# Altium schematic sheet RJ45.SchDoc(RJ45)
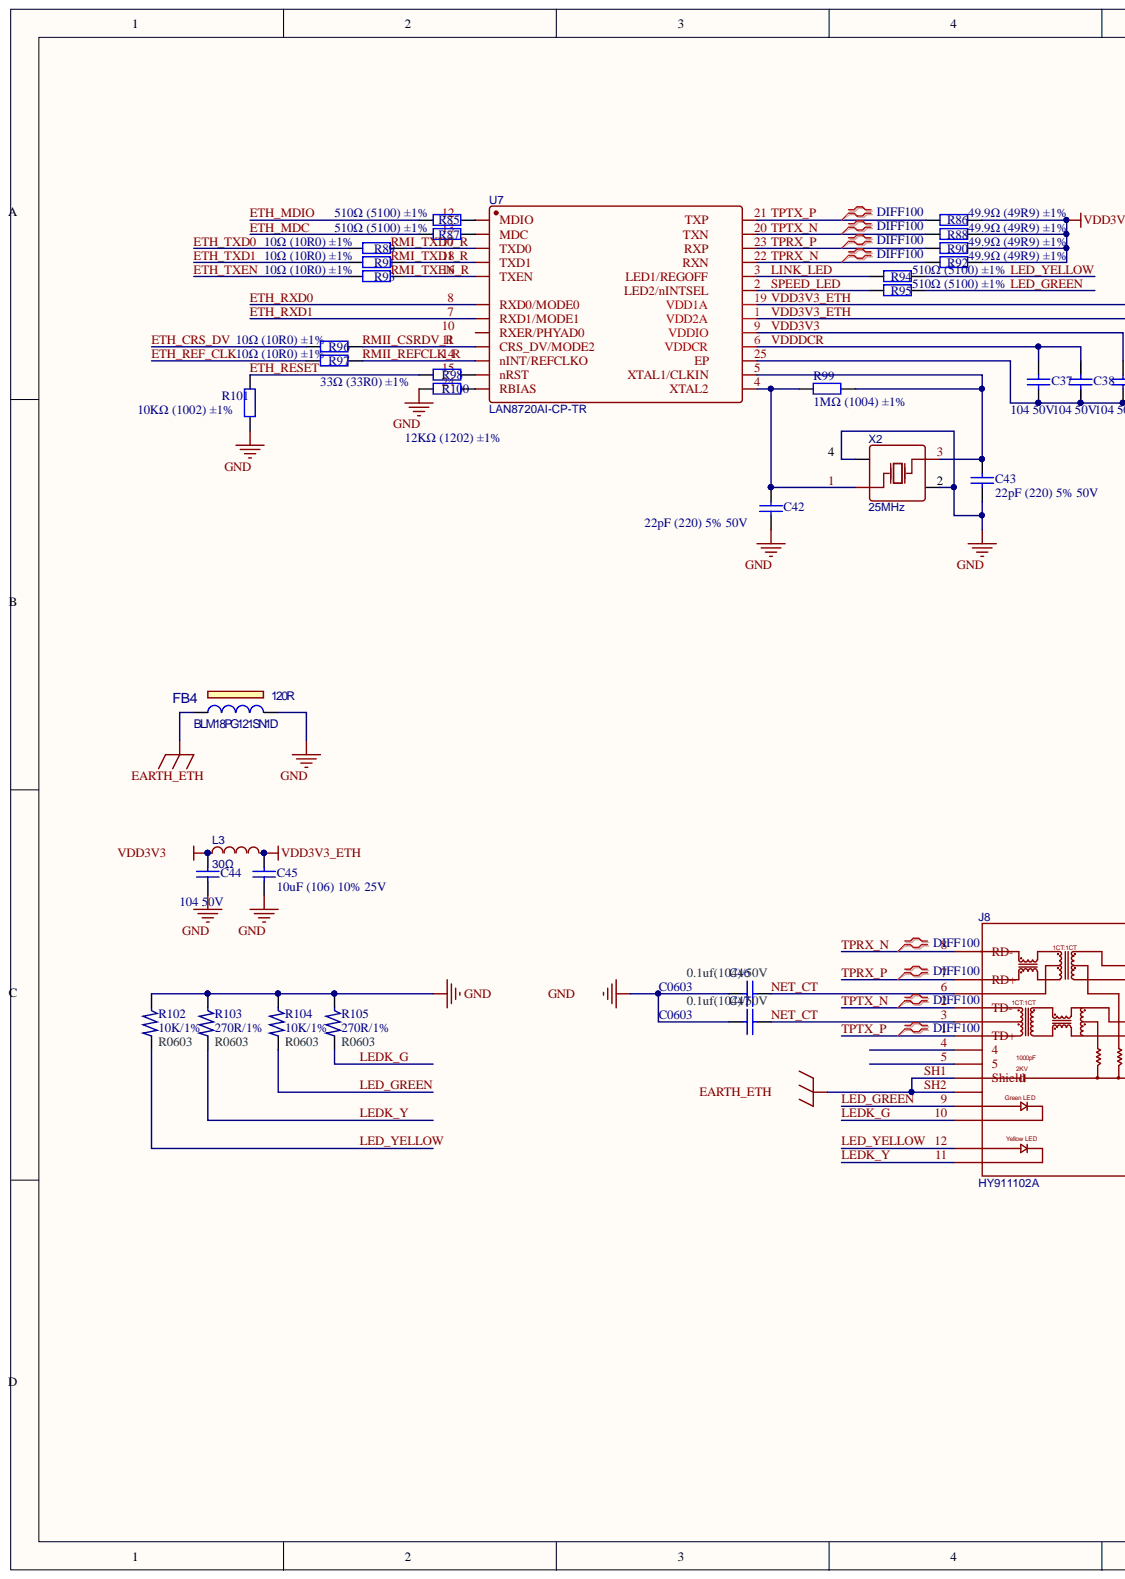
[diagram p0: RJ45.SchDoc, left]
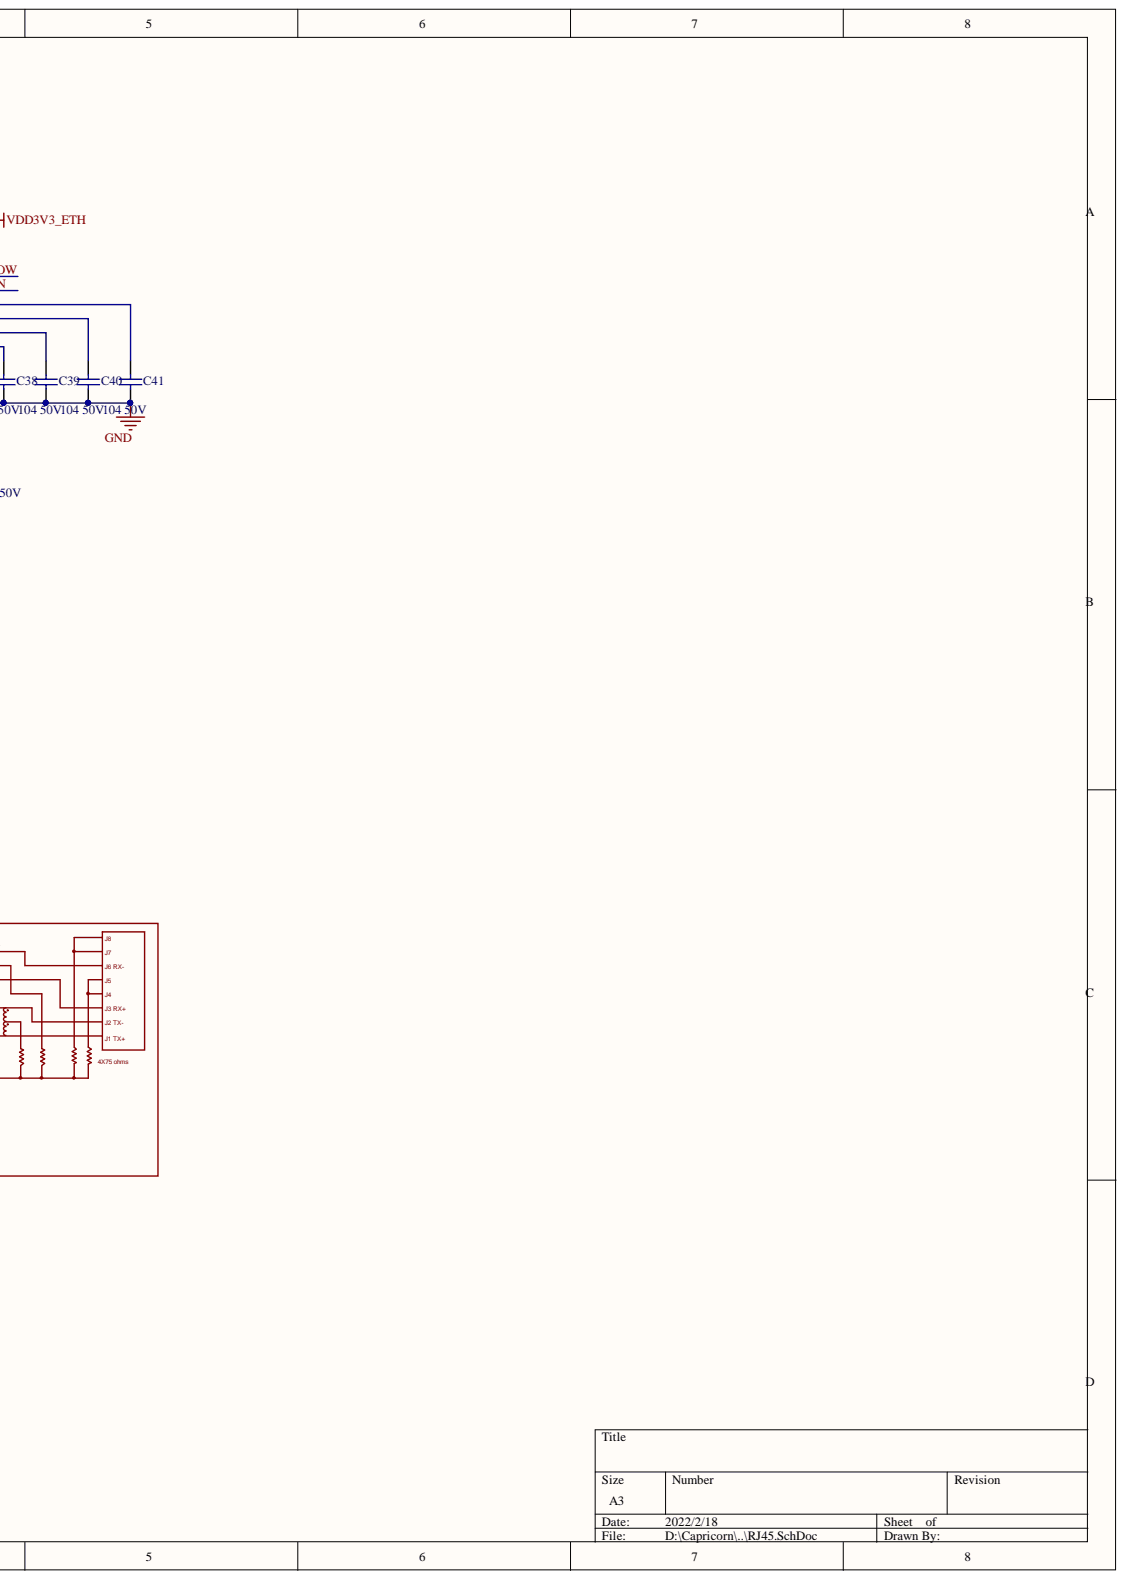
[diagram p1: RJ45.SchDoc, right]

## Parts
C37  104 50V  [0603_C]
C38  104 50V  [0603_C]
C39  104 50V  [0603_C]
C40  104 50V  [0603_C]
C41  104 50V  [0603_C]
C42  22pF (220) 5% 50V  [0603_C]
C43  22pF (220) 5% 50V  [0603_C]
C44  104 50V  [0603_C]
C45  10uF (106) 10% 25V  [0805_C]
C46  0.1uf(104)/50V  value C0603  [0603_C]
C47  0.1uf(104)/50V  value C0603  [0603_C]
FB4  value 120R  Manufacturer Part Number 1: BLM18PG121SN1D  [0603_L]  (hidden comment: Ferrite Bead)
J8  HY911102A  [RJ45-TH_HR911105A]
L3  30Ω  [0603_L]
R85  510Ω (5100) ±1%  [0603_R]
R86  49.9Ω (49R9) ±1%  [0603_R]
R87  510Ω (5100) ±1%  [0603_R]
R88  49.9Ω (49R9) ±1%  [0603_R]
R89  10Ω (10R0) ±1%  [0603_R]
R90  49.9Ω (49R9) ±1%  [0603_R]
R91  10Ω (10R0) ±1%  [0603_R]
R92  49.9Ω (49R9) ±1%  [0603_R]
R93  10Ω (10R0) ±1%  [0603_R]
R94  510Ω (5100) ±1%  [0603_R]
R95  510Ω (5100) ±1%  [0603_R]
R96  10Ω (10R0) ±1%  [0603_R]
R97  10Ω (10R0) ±1%  [0603_R]
R98  33Ω (33R0) ±1%  [0603_R]
R99  1MΩ (1004) ±1%  [0603_R]
R100  12KΩ (1202) ±1%  [0603_R]
R101  10KΩ (1002) ±1%  [0603_R]
R102  10K/1%  [R0603]
R103  270R/1%  [R0603]
R104  10K/1%  [R0603]
R105  270R/1%  [R0603]
U7  LAN8720AI-CP-TR  [QFN-24_4x4x05P]
X2  25MHz  [OSC-SMD_4P-L3.2-W2.5-BL]

## Nets
EARTH_ETH: FB4.1 J8.SH1(Shield) J8.SH2
GND: C37.1 C38.1 C39.1 C40.1 C41.1 C42.1 C43.1 C44.1 C45.1 C46.2 C47.2 FB4.2 R100.1 R101.1 R102.2 R103.2 R104.2 R105.2 U7.25 X2.2 X2.4
ETH_CRS_DV: R96.1
ETH_MDC: R87.1
ETH_MDIO: R85.1
ETH_REF_CLK: R97.1
ETH_RESET: R98.1 R101.2
ETH_RXD0: U7.8(RXD0/MODE0)
ETH_RXD1: U7.7(RXD1/MODE1)
ETH_TXD0: R89.1
ETH_TXD1: R91.1
ETH_TXEN: R93.1
LED_GREEN: J8.9 R95.2 R104.1
LED_YELLOW: J8.12 R94.2 R102.1
LEDK_G: J8.10 R105.1
LEDK_Y: J8.11 R103.1
LINK_LED: R94.1 U7.3
NET_CT: C46.1 C47.1 J8.3 J8.6
RMII_CSRDV_R: R96.2 U7.11(CRS_DV/MODE2)
RMII_REFCLK_R: R97.2 U7.14(nINT/REFCLKO)
SPEED_LED: R95.1 U7.2
TPRX_N: J8.8(RD-) R92.1 U7.22
TPRX_P: J8.7(RD+) R90.1 U7.23
TPTX_N: J8.2(TD-) R88.1 U7.20
TPTX_P: J8.1(TD+) R86.1 U7.21
VDD3V3: C39.2 C44.2 L3.1 U7.9
VDD3V3_ETH: C40.2 C41.2 C45.2 L3.2 R86.2 R88.2 R90.2 R92.2 U7.1 U7.19
VDDDCR: C37.2 C38.2 U7.6
NetC42_2: C42.2 R99.1 U7.4 X2.1
NetC43_2: C43.2 R99.2 U7.5 X2.3
NetR85_2: R85.2 U7.12(MDIO)
NetR87_2: R87.2 U7.13(MDC)
NetR89_2: R89.2 U7.17(TXD0)
NetR91_2: R91.2 U7.18(TXD1)
NetR93_2: R93.2 U7.16(TXEN)
NetR98_2: R98.2 U7.15(nRST)
NetR100_2: R100.2 U7.24(RBIAS)
RMI_TXD0_R:
RMI_TXD1_R:
RMI_TXEN_R:

Not connected: J8 4 5; U7 10(RXER/PHYAD0)

## Library data of the parts
C37-C41 C44 = 0603 100nF (104) 10% 50V: 贴片电容; Component Kind: Standard; ComponentLink1Description: 供应商链接; ComponentLink1URL: http://www.szlcsc.com/product/details_15331.html; Library Name: Miscellaneous Devices LC.IntLib; Package: 0603; Supplier: LC; SuppliersPartNumber: C14663
C42 C43 = 0603 22pF (220) 5% 50V: 贴片电容; Component Kind: Standard; ComponentLink1Description: 供应商链接; ComponentLink1URL: http://www.szlcsc.com/product/details_2005.html; Library Name: Miscellaneous Devices LC.IntLib; Package: 0603; Supplier: LC; SuppliersPartNumber: C1653
C45 = 0805 10uF (106) 10% 25V: 贴片电容; Component Kind: Standard; ComponentLink1Description: 供应商链接; ComponentLink1URL: http://www.szlcsc.com/product/details_16532.html; Library Name: Miscellaneous Devices LC.IntLib; Package: 0805; Supplier: LC; SuppliersPartNumber: C15850
C46 C47 = CAP: Capacitor; Component Kind: Standard
FB4 = IND1009: Manufacturer 1: Murata; Database Table Name: Inductor; DCR: 0.05R; Description_: FERRITE 120R@100MHz 2A SMD 0603; Dev Tools Status: Production; Owner: Petnarong Chaijaroong; Populated: Yes; Saturation Current: 12A; Category: Inductor; Component Kind: Standard; ComponentLink1Description: SVN Datasheet; ComponentLink1URL: https://chn-vm-apps.microchip.com/mchprepo/parts/Datasheets/L0110S0100BLM18P.pdf; ComponentLink2Description: Local Datasheet; ComponentLink3Description: Open Symbol; ComponentLink4Description: Open Footprint; ComponentLink5Description: Open Footprint 2; ComponentLink6Description: Open Footprint 3; ComponentLink7Description: Open Footprint 4; Library Name: MCHP-CDB.DbLib; MCHP-ID: IND1009; Operating Temperature: -55C to +125C; Package: 0603; Quantity Override: 1; Revision: 0; Status: MCL Design; Supplier 1: Digi-Key; Supplier Part Number 1: 490-1037-1-ND; Symbol: Ferrite Bead; Type: Ferrite Bead; ComponentLink2URL: C:\ALTIUM_WORK\00001_MCHP-CDB\Datasheets\L0110S0100BLM18P.pdf; ComponentLink3URL: C:\ALTIUM_WORK\00001_MCHP-CDB\Library\LibraryRefs\Ferrite Bead.SchLib; ComponentLink4URL: C:\ALTIUM_WORK\00001_MCHP-CDB\Library\Footprints\IND_C_0603.PcbLib
J8 = HY911102A: BOM_Manufacturer: HANRUN; BOM_JLCPCB Part Class: Extended Part; BOM_Manufacturer Part: HY911102A; BOM_Supplier: LCSC; BOM_Supplier Part: C21842; Component Kind: Standard; Library Name: 元件库.SCHLIB; spicePre: J; spiceSymbolName: HY911102A
L3 = 30Ω: BOM_Manufacturer: Sunlord; BOM_Manufacturer Part: GZ1608D300TF; nameAlias: Value; BOM_JLCPCB Part Class: Extended Part; BOM_Supplier: LCSC; BOM_Supplier Part: C24821; Component Kind: Standard; Library Name: 元件库.SCHLIB; spicePre: L; spiceSymbolName: GZ1608D300TF
R85 R87 R94 R95 = 0603 510Ω (5100) 1%: 贴片电阻; Component Kind: Standard; ComponentLink1Description: 供应商链接; ComponentLink1URL: http://www.szlcsc.com/product/details_23920.html; Library Name: Miscellaneous Devices LC.IntLib; Package: 0603; Supplier: LC; SuppliersPartNumber: C23193
R86 R88 R90 R92 = 0603 49.9Ω (49R9) 1%: 贴片电阻; Component Kind: Standard; ComponentLink1Description: 供应商链接; ComponentLink1URL: http://www.szlcsc.com/product/details_23912.html; Library Name: Miscellaneous Devices LC.IntLib; Package: 0603; Supplier: LC; SuppliersPartNumber: C23185
R89 R91 R93 R96 R97 = 0603 10Ω (10R0) 1%: 贴片电阻; Component Kind: Standard; ComponentLink1Description: 供应商链接; ComponentLink1URL: http://www.szlcsc.com/product/details_23586.html; Library Name: Miscellaneous Devices LC.IntLib; Package: 0603; Supplier: LC; SuppliersPartNumber: C22859
R98 = 0603 33Ω (33R0) 1%: 贴片电阻; Component Kind: Standard; ComponentLink1Description: 供应商链接; ComponentLink1URL: http://www.szlcsc.com/product/details_23867.html; Library Name: Miscellaneous Devices LC.IntLib; Package: 0603; Supplier: LC; SuppliersPartNumber: C23140
R99 = 0603 1MΩ (1004) 1%: 贴片电阻; Component Kind: Standard; ComponentLink1Description: 供应商链接; ComponentLink1URL: http://www.szlcsc.com/product/details_23662.html; Library Name: Miscellaneous Devices LC.IntLib; Package: 0603; Supplier: LC; SuppliersPartNumber: C22935
R100 = 0603 12KΩ (1202) 1%: 贴片电阻; Component Kind: Standard; ComponentLink1Description: 供应商链接; ComponentLink1URL: http://www.szlcsc.com/product/details_23517.html; Library Name: Miscellaneous Devices LC.IntLib; Package: 0603; Supplier: LC; SuppliersPartNumber: C22790
R101 = 0603 10KΩ (1002) 1%: 贴片电阻; Component Kind: Standard; ComponentLink1Description: 供应商链接; ComponentLink1URL: http://www.szlcsc.com/product/details_26547.html; Library Name: Miscellaneous Devices LC.IntLib; Package: 0603; Supplier: LC; SuppliersPartNumber: C25804
R102-R105 = 电阻: Component Kind: Standard
U7 = LAN8720AI-CP-TR: BOM_Manufacturer: SMSC; BOM_JLCPCB Part Class: Extended Part; BOM_Manufacturer Part: LAN8720AI-CP-TR; BOM_Supplier: LCSC; BOM_Supplier Part: C17146; Component Kind: Standard; Library Name: 元件库.SCHLIB; spicePre: U; spiceSymbolName: LAN8720AI-CP-TR
X2 = 25MHz: BOM_Manufacturer: YXC; BOM_Manufacturer Part: X322525MOB4SI; nameAlias: Frequency; BOM_JLCPCB Part Class: Basic Part; BOM_Supplier: LCSC; BOM_Supplier Part: C9006; Component Kind: Standard; Library Name: 元件库.SCHLIB; spicePre: X; spiceSymbolName: X322525MOB4SI
